# Altium schematic sheet CAN Breakout.SchDoc
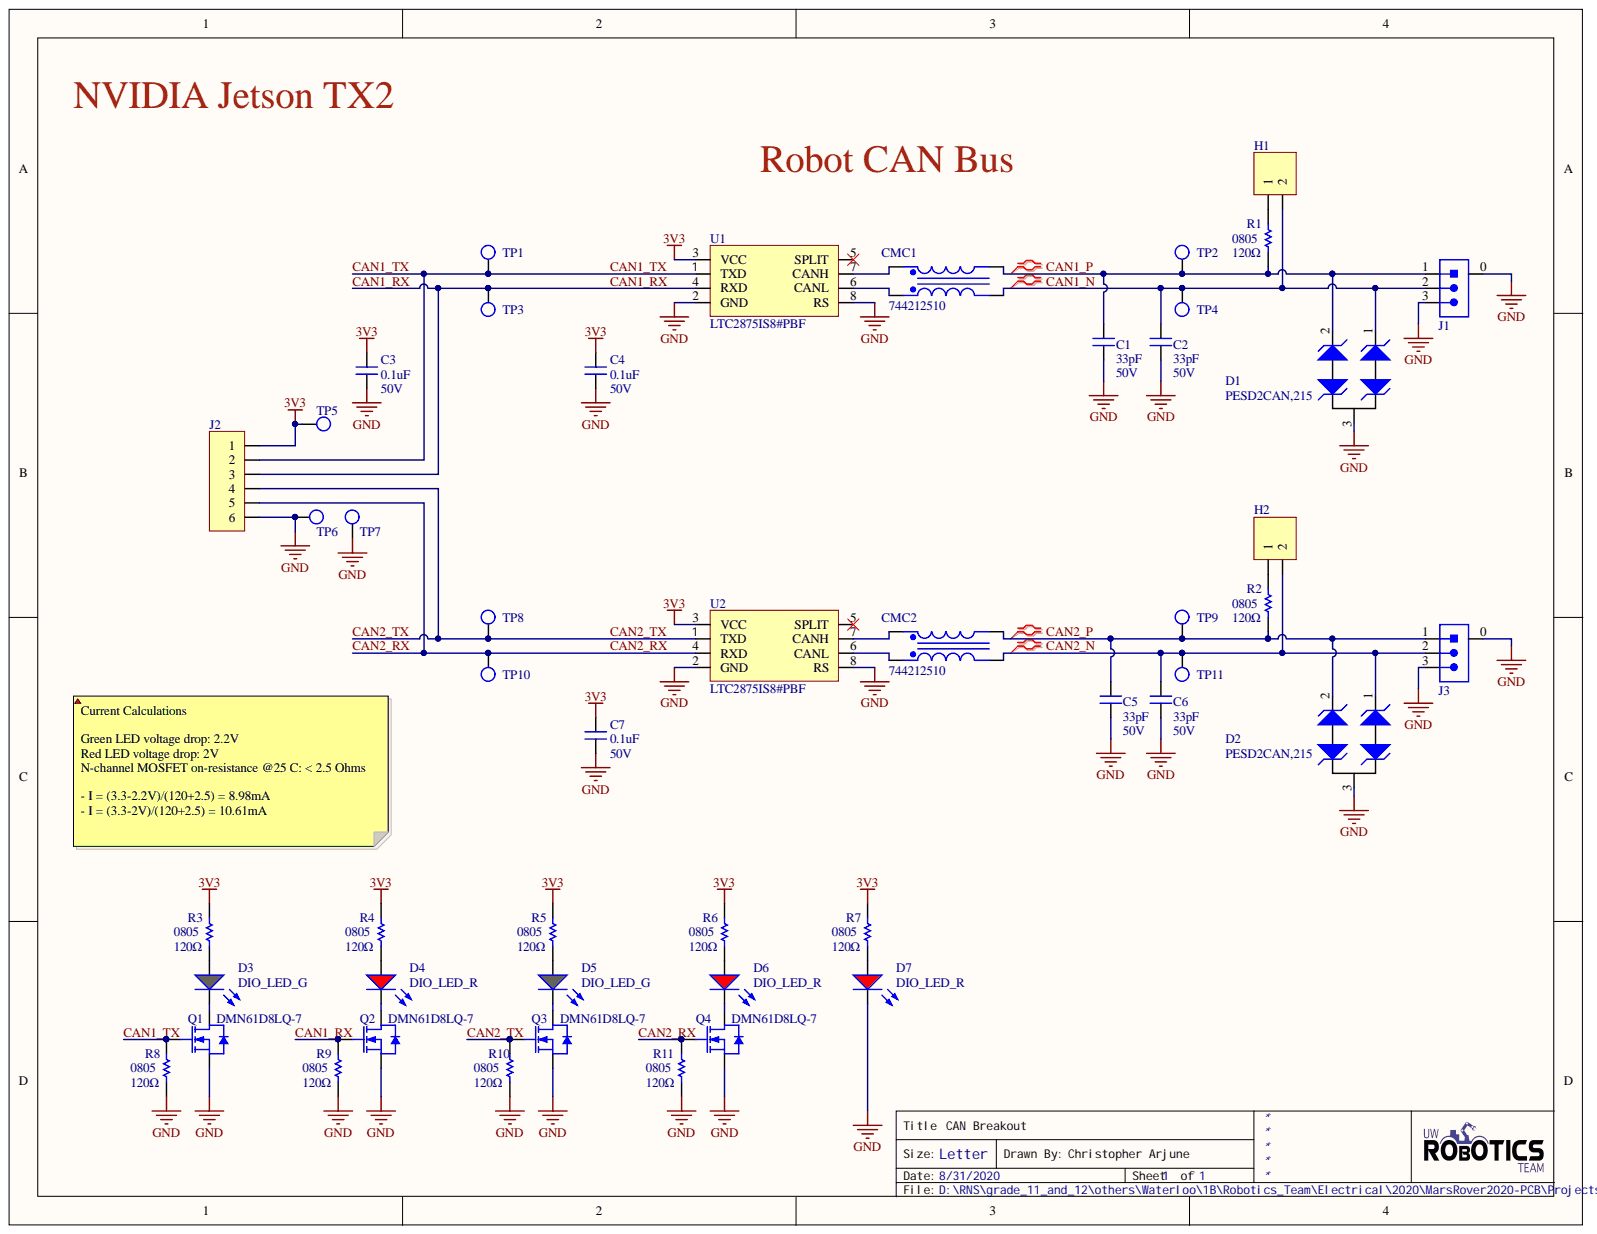
[diagram p0: CAN Breakout.SchDoc]

## Parts
C1  value 33pF  Voltage: 50V  [CAPC0603(1608)55_N]  (hidden comment: CAP_33pF_50V_0603)
C2  value 33pF  Voltage: 50V  [CAPC0603(1608)55_N]  (hidden comment: CAP_33pF_50V_0603)
C3  value 0.1uF  Voltage Rating: 50V  [CAPC2012X140N]  (hidden comment: CAP_0.1uF_50V_0805)
C4  value 0.1uF  Voltage Rating: 50V  [CAPC2012X140N]  (hidden comment: CAP_0.1uF_50V_0805)
C5  value 33pF  Voltage: 50V  [CAPC0603(1608)55_N]  (hidden comment: CAP_33pF_50V_0603)
C6  value 33pF  Voltage: 50V  [CAPC0603(1608)55_N]  (hidden comment: CAP_33pF_50V_0603)
C7  value 0.1uF  Voltage Rating: 50V  [CAPC2012X140N]  (hidden comment: CAP_0.1uF_50V_0805)
CMC1  (no value)  [744212510]
CMC2  (no value)  [744212510]
D1  Manufacturer Part Number 1: PESD2CAN,215  [TVS_DIODE_CAN]  (hidden comment: TVS_DIODE_CAN)
D2  Manufacturer Part Number 1: PESD2CAN,215  [TVS_DIODE_CAN]  (hidden comment: TVS_DIODE_CAN)
D3  DIO_LED_G  [LED_0805_LINE]
D4  DIO_LED_R  [LED_0805_LINE]
D5  DIO_LED_G  [LED_0805_LINE]
D6  DIO_LED_R  [LED_0805_LINE]
D7  DIO_LED_R  [LED_0805_LINE]
H1  JUMPER_2  [HDR1X2]  (value not drawn)
H2  JUMPER_2  [HDR1X2]  (value not drawn)
J1  CON_3M_SM4  [JST-B3B-SM4-TB]  (value not drawn)
J2  (no value)  [OSTYK51106030]
J3  CON_3M_SM4  [JST-B3B-SM4-TB]  (value not drawn)
Q1  Manufacturer Part Number 1: DMN61D8LQ-7  [SOT23-3]
Q2  Manufacturer Part Number 1: DMN61D8LQ-7  [SOT23-3]
Q3  Manufacturer Part Number 1: DMN61D8LQ-7  [SOT23-3]
Q4  Manufacturer Part Number 1: DMN61D8LQ-7  [SOT23-3]
R1  120  Case Code (Imperial): 0805  Resistance: 120Ω  [RESC2012X60N]
R2  120  Case Code (Imperial): 0805  Resistance: 120Ω  [RESC2012X60N]
R3  120  Case Code (Imperial): 0805  Resistance: 120Ω  [RESC2012X60N]
R4  120  Case Code (Imperial): 0805  Resistance: 120Ω  [RESC2012X60N]
R5  120  Case Code (Imperial): 0805  Resistance: 120Ω  [RESC2012X60N]
R6  120  Case Code (Imperial): 0805  Resistance: 120Ω  [RESC2012X60N]
R7  120  Case Code (Imperial): 0805  Resistance: 120Ω  [RESC2012X60N]
R8  120  Case Code (Imperial): 0805  Resistance: 120Ω  [RESC2012X60N]
R9  120  Case Code (Imperial): 0805  Resistance: 120Ω  [RESC2012X60N]
R10  120  Case Code (Imperial): 0805  Resistance: 120Ω  [RESC2012X60N]
R11  120  Case Code (Imperial): 0805  Resistance: 120Ω  [RESC2012X60N]
TP1  TESTPOINT_LOOP  [TESTPOINT_LOOP]  (value not drawn)
TP2  TESTPOINT_LOOP  [TESTPOINT_LOOP]  (value not drawn)
TP3  TESTPOINT_LOOP  [TESTPOINT_LOOP]  (value not drawn)
TP4  TESTPOINT_LOOP  [TESTPOINT_LOOP]  (value not drawn)
TP5  TESTPOINT_LOOP  [TESTPOINT_LOOP]  (value not drawn)
TP6  TESTPOINT_LOOP  [TESTPOINT_LOOP]  (value not drawn)
TP7  TESTPOINT_LOOP  [TESTPOINT_LOOP]  (value not drawn)
TP8  TESTPOINT_LOOP  [TESTPOINT_LOOP]  (value not drawn)
TP9  TESTPOINT_LOOP  [TESTPOINT_LOOP]  (value not drawn)
TP10  TESTPOINT_LOOP  [TESTPOINT_LOOP]  (value not drawn)
TP11  TESTPOINT_LOOP  [TESTPOINT_LOOP]  (value not drawn)
U1  Manufacturer Part Number 1: LTC2875IS8#PBF  [LT-S8_N]  (hidden comment: IC TXRX CAN)
U2  Manufacturer Part Number 1: LTC2875IS8#PBF  [LT-S8_N]  (hidden comment: IC TXRX CAN)

## Nets
3V3: C3.2 C4.2 C7.2 J2.1 R3.2 R4.2 R5.2 R6.2 R7.2 TP5.1 U1.3(VCC) U2.3(VCC)
GND: C1.1 C2.1 C3.1 C4.1 C5.1 C6.1 C7.1 D1.3 D2.3 D7.2 J1.0 J1.3 J2.6 J3.0 J3.3 Q1.2 Q2.2 Q3.2 Q4.2 R8.1 R9.1 R10.1 R11.1 TP6.1 TP7.1 U1.2(GND) U1.8(RS) U2.2(GND) U2.8(RS)
CAN1_N: C2.2 CMC1.5 D1.1 H1.2 J1.2 TP4.1
CAN1_P: C1.2 CMC1.4 D1.2 J1.1 R1.1 TP2.1
CAN1_RX: J2.3 Q2.1 R9.2 TP3.1 U1.4(RXD)
CAN1_TX: J2.2 Q1.1 R8.2 TP1.1 U1.1(TXD)
CAN2_N: C6.2 CMC2.5 D2.1 H2.2 J3.2 TP11.1
CAN2_P: C5.2 CMC2.4 D2.2 J3.1 R2.1 TP9.1
CAN2_RX: J2.5 Q4.1 R11.2 TP10.1 U2.4(RXD)
CAN2_TX: J2.4 Q3.1 R10.2 TP8.1 U2.1(TXD)
NetCMC1_1: CMC1.1 U1.6(CANL)
NetCMC1_3: CMC1.3 U1.7(CANH)
NetCMC2_1: CMC2.1 U2.6(CANL)
NetCMC2_3: CMC2.3 U2.7(CANH)
NetD3_1: D3.1 R3.1
NetD3_2: D3.2 Q1.3
NetD4_1: D4.1 R4.1
NetD4_2: D4.2 Q2.3
NetD5_1: D5.1 R5.1
NetD5_2: D5.2 Q3.3
NetD6_1: D6.1 R6.1
NetD6_2: D6.2 Q4.3
NetD7_1: D7.1 R7.1
NetH1_1: H1.1 R1.2
NetH2_1: H2.1 R2.2

Not connected: U1 5(SPLIT); U2 5(SPLIT)

## Library data of the parts
C1 C2 C5 C6 = CAP_33pF_50V_0603: CAP CER 33PF 50V C0G/NP0; Manufacturer 1: Yageo; Manufacturer Part Number 1: CC0603JRNPO9BN330; Dielectric: C0G, NP0; Dielectric Material: Ceramic; Insulation Resistance: 100GR; Temperature Coefficient: 30ppm/°C; Tolerance: 5%; Case Code (Imperial): 0603; Case Code (Metric): 1608; Component Kind: Standard; ComponentLink7Description: https://datasheet.ciiva.com/11464/upy-gp-np0-16v-to-50v-8-11464730.pdf?src-supplier=Avnet; ComponentLink7URL: https://datasheet.ciiva.com/11464/upy-gp-np0-16v-to-50v-8-11464730.pdf?src-supplier=Avnet; ComponentLink8Description: https://datasheet.ciiva.com/46430/1835847-46430155.pdf?src-supplier=Element14; ComponentLink8URL: https://datasheet.ciiva.com/46430/1835847-46430155.pdf?src-supplier=Element14; ComponentLink9Description: https://datasheet.ciiva.com/144/mlccorderingcode-144644.pdf?src-supplier=Digi-Key; ComponentLink9URL: https://datasheet.ciiva.com/144/mlccorderingcode-144644.pdf?src-supplier=Digi-Key; ComponentLink10Description: https://datasheet.ciiva.com/5426/upy-gp-np0-16v-to-50v-8-5426614.pdf?src-supplier=Mouser; ComponentLink10URL: https://datasheet.ciiva.com/5426/upy-gp-np0-16v-to-50v-8-5426614.pdf?src-supplier=Mouser; ComponentLink11Description: https://datasheet.ciiva.com/7978/1443161-7978142.pdf?src-supplier=Element14; ComponentLink11URL: https://datasheet.ciiva.com/7978/1443161-7978142.pdf?src-supplier=Element14; Composition: Ceramic; Depth: 0.8mm; Height: 0.8mm; Length: 1.6mm; Max Operating Temperature: 125°C; Min Operating Temperature: -55°C; Mount: Surface Mount; Number of Pins: 2; Package: 0603; REACH SVHC: No SVHC; Supplier 1: Digi-Key; Supplier Part Number 1: 311-1064-1-ND; Termination: SMD/SMT; Thickness: 0.035inch; Voltage Rating: 50V; Voltage Rating (DC): 50V; Width: 0.031inch; Library Name: UWRT_Schematic_Library_2020.SchLib
C3 C4 C7 = CAP_0.1uF_50V_0805: CAP CER 0.1UF 50V X7R 0805; Manufacturer 1: Murata; Manufacturer Part Number 1: GCD21BR71H104KA01L; Capacitance: 100nF; Tolerance: 10%; Case/Package: 0805; Case Code (Imperial): 0805; Case Code (Metric): 2012; Component Kind: Standard; ComponentLink1Description: https://datasheet.ciiva.com/29537/c03e-29537701.pdf?src-supplier=Digi-Key; ComponentLink1URL: https://datasheet.ciiva.com/29537/c03e-29537701.pdf?src-supplier=Digi-Key; Depth: 1.25mm; Dielectric: X7R; Height: 1.25mm; Length: 2mm; Max Operating Temperature: 125°C; Min Operating Temperature: -55°C; Mount: Surface Mount; Ratings: AEC-Q200; Supplier 1: Digi-Key; Supplier Part Number 1: 490-11955-1-ND; Termination: SMD/SMT; Thickness: 0.049inch; Width: 0.049inch; Library Name: UWRT_Schematic_Library_2020.SchLib
CMC1 CMC2 = CMC_51uH: CMC 51uH 300MA 2LN SMD; Manufacturer 1: Wurth Electronics; Core Material: Ferrite; DC Resistance (DCR): 160mR; Impedance: 300R; Inductance Tolerance: 50%; Number of Lines: 2; Test Frequency: 100kHz; Voltage Rating (DC): 80V; Case/Package: SMD/SMT; Component Kind: Standard; ComponentLink7Description: https://datasheet.ciiva.com/6216/744212510-6216348.pdf?src-supplier=Digi-Key; ComponentLink7URL: https://datasheet.ciiva.com/6216/744212510-6216348.pdf?src-supplier=Digi-Key; ComponentLink8Description: https://datasheet.ciiva.com/7764/1735560-7764951.pdf?src-supplier=Element14; ComponentLink8URL: https://datasheet.ciiva.com/7764/1735560-7764951.pdf?src-supplier=Element14; Current: 300mA; Current Rating: 300mA; Depth: 3.6mm; Height: 1.65mm; Inductance: 51uH; Length: 6.5mm; Manufacturer Part Number 1: 744212510; Max DC Current: 300mA; Max Operating Temperature: 105°C; Min Operating Temperature: -40°C; Mount: Surface Mount; Number of Pins: 4; REACH SVHC: No SVHC; Supplier 1: Digi-Key; Supplier Part Number 1: 732-3941-1-ND; Termination: SMD/SMT; Tolerance: 50%; Width: 0.142inch
D1 D2 = TVS_DIODE_CAN: TVS DIODE 24V 41V SOT323-3; Manufacturer 1: Nexperia USA Inc.; Component Kind: Standard; ComponentLink1Description: Datasheet; ComponentLink1URL: https://assets.nexperia.com/documents/data-sheet/PESD1CAN-U.pdf; Mount: Surface Mount; Package: SC-70, SOT-323; Supplier 1: Digi-Key; Supplier Part Number 1: 1727-3891-1-ND; Library Name: UWRT_Schematic_Library_2020.SchLib
D3 D5 = DIO_LED_G: LED GREEN DIFFUSED 0805 SMD; Manufacturer 1: OSRAM; Manufacturer Part Number 1: LG R971-KN-1; Forward Voltage Drop: 2.2V; Component Kind: Standard; Mount: Surface Mount; Package: 0805 (2012 Metric); Simulation: LED1; Supplier 1: Digi-Key; Supplier Part Number 1: 475-1410-1-ND; Library Name: UWRT_Schematic_Library_2020.SchLib
D4 D6 D7 = DIO_LED_R: LED RED DIFFUSED 0805 SMD; Manufacturer 1: OSRAM; Manufacturer Part Number 1: LS R976-NR-1; Forward Voltage Drop: 2V; Component Kind: Standard; Mount: Surface Mount; Package: 0805 (2012 Metric); Simulation: LED1; Supplier 1: Digi-Key; Supplier Part Number 1: 475-1278-1-ND; Library Name: UWRT_Schematic_Library_2020.SchLib
H1 H2 = JUMPER_2: HEADER 2X1; Manufacturer 1: TE Connectivity; Manufacturer Part Number 1: 87220-2; Component Kind: Standard; Mount: Through Hole; Supplier 1: Digi-Key; Supplier Part Number 1: A26542-ND; Library Name: UWRT_Schematic_Library_2020.SchLib
J1 J3 = CON_3M_SM4: CONN HEADER SMD 3POS 2MM; Manufacturer 1: JST; Manufacturer Part Number 1: B3B-PH-SM4-TB(LF)(SN); Max Current Rating: 2A; Max Voltage Rating: 100V; Component Kind: Standard; ComponentLink1Description: https://datasheet.ciiva.com/11747/0900766b80ddea81-11747684.pdf?src-supplier=RSComponents; ComponentLink1URL: https://datasheet.ciiva.com/11747/0900766b80ddea81-11747684.pdf?src-supplier=RSComponents; Mount: Surface Mount; Pitch: 2mm; Supplier 1: Digi-Key; Supplier Part Number 1: 455-1735-1-ND; Library Name: UWRT_Schematic_Library_2020.SchLib
J2 = TERMINAL_6: Header, 6-Pin; Manufacturer 1: On-Shore Technology; Current Rating: 30A; Number of Circuits: 6; Pitch: 0.374inch; Voltage Rating: 300V; Wire Gauge (Max): 22AWG; Wire Gauge (Min): 10AWG; Color: Black; Component Kind: Standard; ComponentLink1Description: http://www.on-shore.com/wp-content/uploads/2015/09/ostyk511xx030.pdf?src-supplier=Digi-Key; ComponentLink1URL: http://www.on-shore.com/wp-content/uploads/2015/09/ostyk511xx030.pdf?src-supplier=Digi-Key; Flammability Rating: UL94 V-0; Insulation Material: Nylon; Manufacturer Part Number 1: OSTYK51106030; Max Operating Temperature: 115°C; Min Operating Temperature: -40°C; Mount: Through Hole; Number of Rows: 1; Number of Wire Entries: 6; Packaging: Bulk; Pricing 1: 1=2.56000, 10=1.93600, 25=1.79440, 50=1.61340, 100=1.57400, 250=1.37724, 500=1.25920, 1000=1.14115, 5000=1.00343 (USD); RoHS: Yes; Signal Integrity: Connector; Stock 1: 2939; Supplier 1: Digi-Key; Supplier Part Number 1: ED2957-ND
Q1-Q4 = NMOS_60V_470mA: MOSFET N-CH 60V SOT23; Manufacturer 1: Diodes; Continuous Drain Current (ID): 470mA; Input Capacitance: 12.9pF; Max Power Dissipation: 390mW; Rds On Max: 1.8R; Case/Package: TO-236-3; Component Kind: Standard; ComponentLink1Description: http://www.farnell.com/datasheets/2814413.pdf?src-supplier=Farnell; ComponentLink1URL: http://www.farnell.com/datasheets/2814413.pdf?src-supplier=Farnell; ComponentLink2Description: http://www.mouser.com/ds/2/115/DMN61D8LQ-776439.pdf?src-supplier=Mouser; ComponentLink2URL: http://www.mouser.com/ds/2/115/DMN61D8LQ-776439.pdf?src-supplier=Mouser; ComponentLink3Description: https://www.diodes.com/assets/Datasheets/DMN61D8LQ.pdf?src-supplier=Digi-Key; ComponentLink3URL: https://www.diodes.com/assets/Datasheets/DMN61D8LQ.pdf?src-supplier=Digi-Key; Drain to Source Voltage (Vdss): 60V; Max Operating Temperature: 150°C; Min Operating Temperature: -55°C; Mount: Surface Mount; Packaging: Tape and Reel; Pricing 1: 1=0.44000, 10=0.37900, 25=0.35360, 100=0.26260, 500=0.20504, 1000=0.16665 (USD); RoHS: Yes; Stock 1: 72; Supplier 1: Digi-Key; Supplier Part Number 1: DMN61D8LQ-7DICT-ND
R1-R11 = RES_120Ω_0805: RES SMD 120 OHM 1% 1/8W 0805; Manufacturer 1: Vishay; Manufacturer Part Number 1: CRCW0805120RFKEA; Power Rating: 125mW; Voltage Rating: 150V; Case Code (Metric): 2012; Component Kind: Standard; ComponentLink7Description: https://datasheet.ciiva.com/2467/dcrcwe3-2467513.pdf?src-supplier=Digi-Key; ComponentLink7URL: https://datasheet.ciiva.com/2467/dcrcwe3-2467513.pdf?src-supplier=Digi-Key; ComponentLink8Description: https://datasheet.ciiva.com/16852/dcrcwe3-16852841.pdf?src-supplier=Avnet+Europe; ComponentLink8URL: https://datasheet.ciiva.com/16852/dcrcwe3-16852841.pdf?src-supplier=Avnet+Europe; ComponentLink9Description: https://datasheet.ciiva.com/7777/1697337-7777354.pdf?src-supplier=Element14; ComponentLink9URL: https://datasheet.ciiva.com/7777/1697337-7777354.pdf?src-supplier=Element14; ComponentLink10Description: https://datasheet.ciiva.com/16788/70201119-16788154.pdf?src-supplier=Allied+Electronics; ComponentLink10URL: https://datasheet.ciiva.com/16788/70201119-16788154.pdf?src-supplier=Allied+Electronics; ComponentLink11Description: https://datasheet.ciiva.com/7777/1720486-7777567.pdf?src-supplier=Element14; ComponentLink11URL: https://datasheet.ciiva.com/7777/1720486-7777567.pdf?src-supplier=Element14; Mount: Surface Mount; Package: 0805 (2012 Metric); Supplier 1: Digi-Key; Supplier Part Number 1: 541-120CCT-ND
TP1-TP11 = TESTPOINT_LOOP: Loop testpoint through-hole pad; Manufacturer 1: Keystone Electronics; Manufacturer Part Number 1: 5002; Component Kind: Standard; Mount: Through Hole; Supplier 1: Digi-Key; Supplier Part Number 1: 36-5002-ND; Library Name: UWRT_Schematic_Library_2020.SchLib
U1 U2 = LTC2875: IC TXRX CAN 4MBPS 8SO; Manufacturer 1: Linear Technologies; Component Kind: Standard; ComponentLink1Description: Datasheet; ComponentLink1URL: https://www.analog.com/media/en/technical-documentation/data-sheets/2875f.pdf; Mount: Surface Mount; Package: 8-SOIC (0.154", 3.90mm Width); Supplier 1: Digi-Key; Supplier Part Number 1: LTC2875IS8#PBF-ND; Library Name: UWRT_Schematic_Library_2020.SchLib
